# Altium schematic sheet PW_DB1.SchDoc
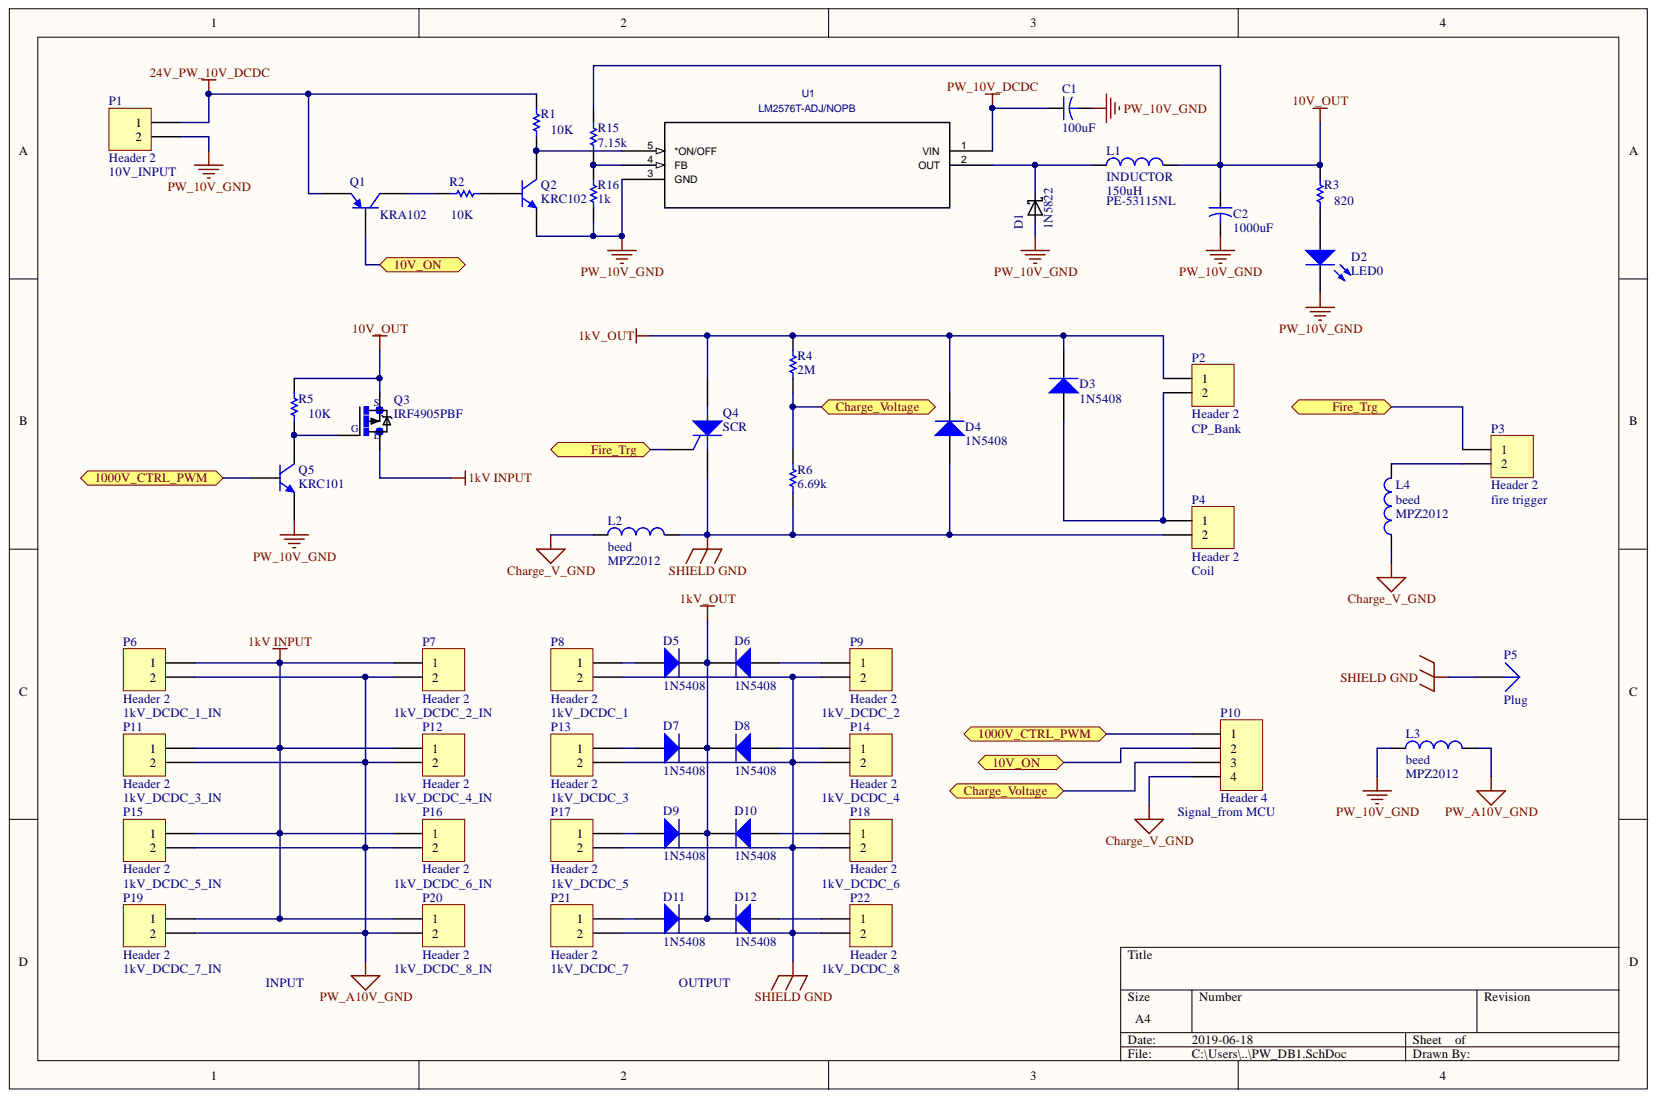
[diagram p0: PW_DB1.SchDoc]

## Parts
C1  value 100uF  [CAPR5-4X5]  (hidden comment: Cap2)
C2  value 1000uF  [CAPR5-4X5]  (hidden comment: Cap2)
D1  1N5822  [*DIOAD2120W130L950D530P_1N5822(Primary)]
D2  LED0  [LED-0]
D3  1N5408  [DO-201AD]
D4  1N5408  [DO-201AD]
D5  1N5408  [DO-201AD]
D6  1N5408  [DO-201AD]
D7  1N5408  [DO-201AD]
D8  1N5408  [DO-201AD]
D9  1N5408  [DO-201AD]
D10  1N5408  [DO-201AD]
D11  1N5408  [DO-201AD]
D12  1N5408  [DO-201AD]
L1  INDUCTOR  value 150uH  [0402-A]
L2  beed  value MPZ2012  [0402-A]
L3  beed  value MPZ2012  [0402-A]
L4  beed  value MPZ2012  [0402-A]
P1  Header 2  [HDR1X2]
P2  Header 2  [HDR1X2]
P3  Header 2  [HDR1X2]
P4  Header 2  [HDR1X2]
P5  Plug  [PIN1]
P6  Header 2  [HDR1X2]
P7  Header 2  [HDR1X2]
P8  Header 2  [HDR1X2]
P9  Header 2  [HDR1X2]
P10  Header 4  [HDR1X4]
P11  Header 2  [HDR1X2]
P12  Header 2  [HDR1X2]
P13  Header 2  [HDR1X2]
P14  Header 2  [HDR1X2]
P15  Header 2  [HDR1X2]
P16  Header 2  [HDR1X2]
P17  Header 2  [HDR1X2]
P18  Header 2  [HDR1X2]
P19  Header 2  [HDR1X2]
P20  Header 2  [HDR1X2]
P21  Header 2  [HDR1X2]
P22  Header 2  [HDR1X2]
Q1  KRA102  [SOT-23B_N]
Q2  KRC102  [SOT-23B_N]
Q3  IRF4905PBF  [*TO220BH_IRF4905PBF(Primary)]
Q4  SCR  [TO-220-AB]
Q5  KRC101  [SOT-23B_N]
R1  value 10K  [AXIAL-0.3]  (hidden comment: Res1)
R2  value 10K  [AXIAL-0.3]  (hidden comment: Res1)
R3  value 820  [AXIAL-0.3]  (hidden comment: Res1)
R4  value 2M  [AXIAL-0.3]  (hidden comment: Res1)
R5  value 10K  [AXIAL-0.3]  (hidden comment: Res1)
R6  value 6.69k  [AXIAL-0.3]  (hidden comment: Res1)
R15  value 7.15k  [AXIAL-0.3]  (hidden comment: Res1)
R16  value 1k  [AXIAL-0.3]  (hidden comment: Res1)
U1  LM2576T-ADJ/NOPB  [T05A]

## Ports
10V_ON, 1000V_CTRL_PWM, CHARGE_VOLTAGE, FIRE_TRG

## Nets
1KV INPUT: P6.1 P7.1 P11.1 P12.1 P15.1 P16.1 P19.1 P20.1 Q3.D
1KV_OUT: D3.2 D4.2 D5.2 D6.2 D7.2 D8.2 D9.2 D10.2 D11.2 D12.2 P2.1 Q4.1 R4.2
10V_OUT: C2.1 L1.2 Q3.S R3.1 R5.1 R15.1
24V_PW_10V_DCDC: P1.1 Q1.3 R1.1
CHARGE_V_GND: L2.1 L4.1 P10.4
PW_10V_DCDC: C1.1 U1.1(VIN)
PW_10V_GND: C1.2 C2.2 D1.A D2.2 L3.1 P1.2 Q2.3 Q5.3 R16.2 U1.3(GND)
PW_A10V_GND: L3.2 P6.2 P7.2 P11.2 P12.2 P15.2 P16.2 P19.2 P20.2
SHIELD GND: D4.1 L2.2 P4.2 P5.1 P8.2 P9.2 P13.2 P14.2 P17.2 P18.2 P21.2 P22.2 Q4.3 R6.2
10V_ON: P10.2 Q1.2 ; port 10V_ON
1000V_CTRL_PWM: P10.1 Q5.2 ; port 1000V_CTRL_PWM
NetD1_C: D1.C L1.1 U1.2(OUT)
NetD2_1: D2.1 R3.2
NetD3_1: D3.1 P2.2 P4.1
NetD5_1: D5.1 P8.1
NetD6_1: D6.1 P9.1
NetD7_1: D7.1 P13.1
NetD8_1: D8.1 P14.1
NetD9_1: D9.1 P17.1
NetD10_1: D10.1 P18.1
NetD11_1: D11.1 P21.1
NetD12_1: D12.1 P22.1
NetL4_2: L4.2 P3.2
NetP3_1: P3.1 Q4.2 ; port FIRE_TRG
NetP10_3: P10.3 R4.1 R6.1 ; port CHARGE_VOLTAGE
NetQ1_1: Q1.1 R2.2
NetQ2_1: Q2.1 R1.2 U1.5(*ON/OFF)
NetQ2_2: Q2.2 R2.1
NetQ3_G: Q3.G Q5.1 R5.2
NetR15_2: R15.2 R16.1 U1.4(FB)

## Library data of the parts
C1 C2 = Cap2: Capacitor; Component Kind: Standard; Library Name: Miscellaneous Devices.IntLib; PackageDescription: Capacitor; 2 Leads; PackageReference: CAPR5-4X5; Published: 23-Sep-2002; Signal Integrity: Cap; Simulation: CAP; Publisher: Altium Limited
D1 = 1N5822: MF: ON Semiconductor; Availability: Unavailable; Component Kind: Standard; Library Name: 1N5822.IntLib; MP: 1N5822; Package: DO-201AD-201 CDIL; Price: None; SnapEDA_Link: https://www.snapeda.com/parts/1N5822/ON%20Semiconductor/view-part/?ref=snap
D2 = LED0: Typical INFRARED GaAs LED; Component Kind: Standard; LatestRevisionDate: 08-Jul-2005; LatestRevisionNote: Mech Layer 1 removed.; Library Name: Miscellaneous Devices.IntLib; PackageDescription: LED; 2 Leads; PackageReference: LED-0; Published: 8-Jun-2000; Simulation: LED0; Publisher: Altium Limited
D3-D12 = Diode 1N5408: 3 Amp Medium Power Silicon Rectifier Diode; Component Kind: Standard; ComponentLink1Description: Manufacturer Link; ComponentLink1URL: http://www.irf.com/; DatasheetVersion: Aug-1984; LatestRevisionDate: 17-Jul-2002; LatestRevisionNote: Re-released for DXP Platform.; Library Name: Miscellaneous Devices.IntLib; PackageDescription: Diode, Axial; Body 9.5 x 5.3 mm (LxDia. max), Lead Dia. 1.32 mm (max); PackageReference: DO-201AD; Published: 8-Jun-2000; Signal Integrity: 1N5408; Simulation: 1N5408; Publisher: Altium Limited
L1-L4 = Inductor: Code_IEC: 1005; Code_JEITA: 0402; Component Kind: Standard; Description: Inductor; LatestRevisionDate: 29-May-2009; LatestRevisionNote: IPC-7351 Footprint Added.; Library Name: Miscellaneous Devices.IntLib; PackageDescription: Chip Inductor; PackageReference: 0402-A; Published: 8-Jun-2000; Signal Integrity: Inductor; Simulation: INDUCTOR; Publisher: Altium Limited
P1-P4 P6-P9 P11-P22 = Header 2: Header, 2-Pin; Component Kind: Standard; LatestRevisionDate: 17-Jul-2002; LatestRevisionNote: Re-released for DXP Platform.; Library Name: Miscellaneous Connectors.IntLib; Signal Integrity: Connector; Publisher: Altium Limited
P10 = Header 4: Header, 4-Pin; Component Kind: Standard; LatestRevisionDate: 17-Jul-2002; LatestRevisionNote: Re-released for DXP Platform.; Library Name: Miscellaneous Connectors.IntLib; Signal Integrity: Connector; Publisher: Altium Limited
Q1 = PNP: PNP Bipolar Transistor; Component Kind: Standard; LatestRevisionDate: 29-May-2009; LatestRevisionNote: IPC-7351 Footprint Added.; Library Name: Miscellaneous Devices.IntLib; PackageDescription: 3-Pin SOT-23 Package 0.95 mm Pitch, 2.4 mm Lead Span; PackageReference: SOT-23B; PackageVersion: Aug-1999; Published: 8-Jun-2000; Signal Integrity: PNP; Simulation: PNP; Publisher: Altium Limited
Q2 Q5 = QNPN: NPN Bipolar Transistor; Component Kind: Standard; LatestRevisionDate: 29-May-2009; LatestRevisionNote: IPC-7351 Footprint Added.; Library Name: Miscellaneous Devices.IntLib; PackageDescription: 3-Pin SOT-23 Package 0.95 mm Pitch, 2.4 mm Lead Span; PackageReference: SOT-23B; PackageVersion: Aug-1999; Published: 8-Jun-2000; Signal Integrity: NPN; Simulation: QNPN; Publisher: Altium Limited
Q3 = IRF4905PBF: MOSFET, Power; P-Ch; VDSS -55V; RDS_ON_ 0.02Ohm; ID -74A; TO-220AB; PD 200W; VGS +/-20V; MF: Infineon; Availability: Unavailable; Component Kind: Standard; Library Name: IRF4905PBF.IntLib; MP: IRF4905PBF; Package: TO-220 Infineon; Price: None; SnapEDA_Link: https://www.snapeda.com/parts/IRF4905PBF/Infineon%20Technologies/view-part/?ref=snap
Q4 = SCR: Silicon Controlled Rectifier; Code_JEDEC: TO-220-AB; Component Kind: Standard; LatestRevisionDate: 17-Jul-2002; LatestRevisionNote: Re-released for DXP Platform.; Library Name: Miscellaneous Devices.IntLib; PackageReference: TO-220-AB; Published: 8-Jun-2000; Simulation: SCR; Publisher: Altium Limited
R1-R6 R15 R16 = Res1: Resistor; Component Kind: Standard; LatestRevisionDate: 17-Jul-2002; LatestRevisionNote: Re-released for DXP Platform.; Library Name: Miscellaneous Devices.IntLib; PackageDescription: Axial Device, Thru-Hole; 2 Leads; 0.3 in Pin Spacing; PackageReference: AXIAL-0.3; Published: 8-Jun-2000; Signal Integrity: Res; Simulation: RESISTOR; Publisher: Altium Limited
U1 = LM2576T-ADJ/NOPB: Built_By: EMA_UL_Team; C: Copyright (C) 2015 Accelerated Designs. All rights reserved; Vendor: Texas Instruments; Component Kind: Standard; Library Name: LM2576TADJ.SchLib; Manufacturer_Part_Number: LM2576T-ADJ/NOPB; Value: LM2576T-ADJ/NOPB
P5 = Plug: Component Kind: Standard; Description: Plug; LatestRevisionDate: 17-Jul-2002; LatestRevisionNote: Re-released for DXP Platform.; Library Name: Miscellaneous Connectors.IntLib; Signal Integrity: Connector; Publisher: Altium Limited
